# Altium schematic sheet XDS100V3_JTAG.SchDoc
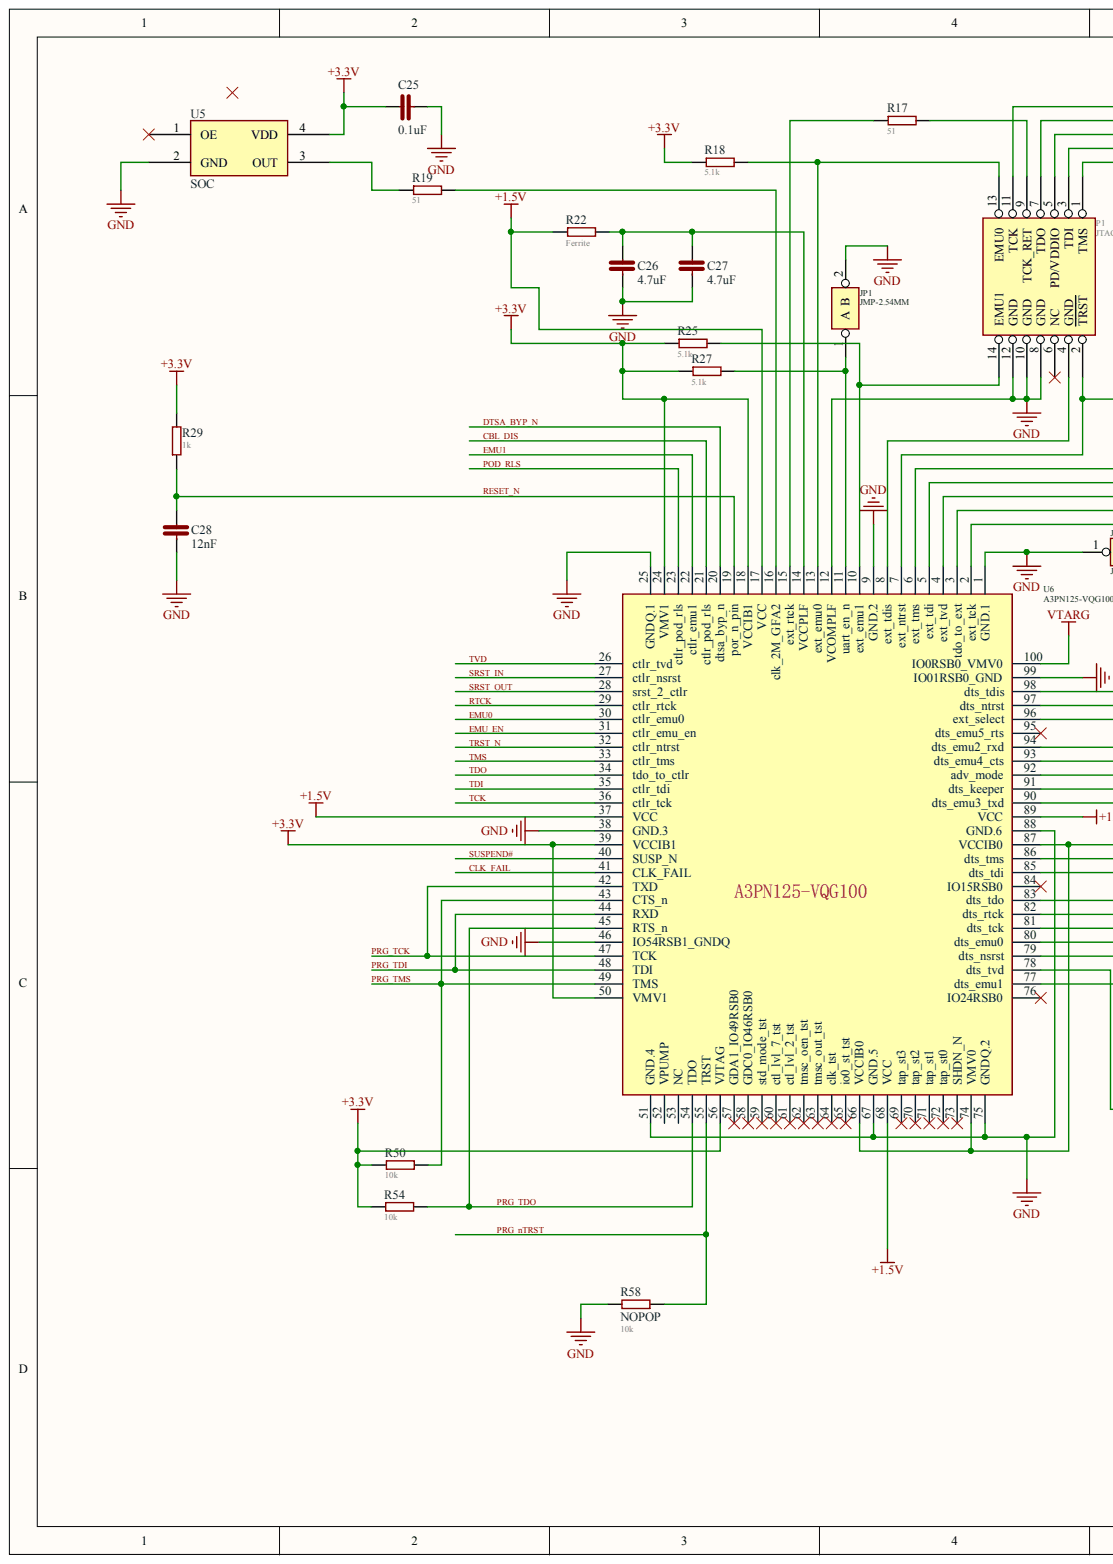
[diagram p0: XDS100V3_JTAG.SchDoc, left]
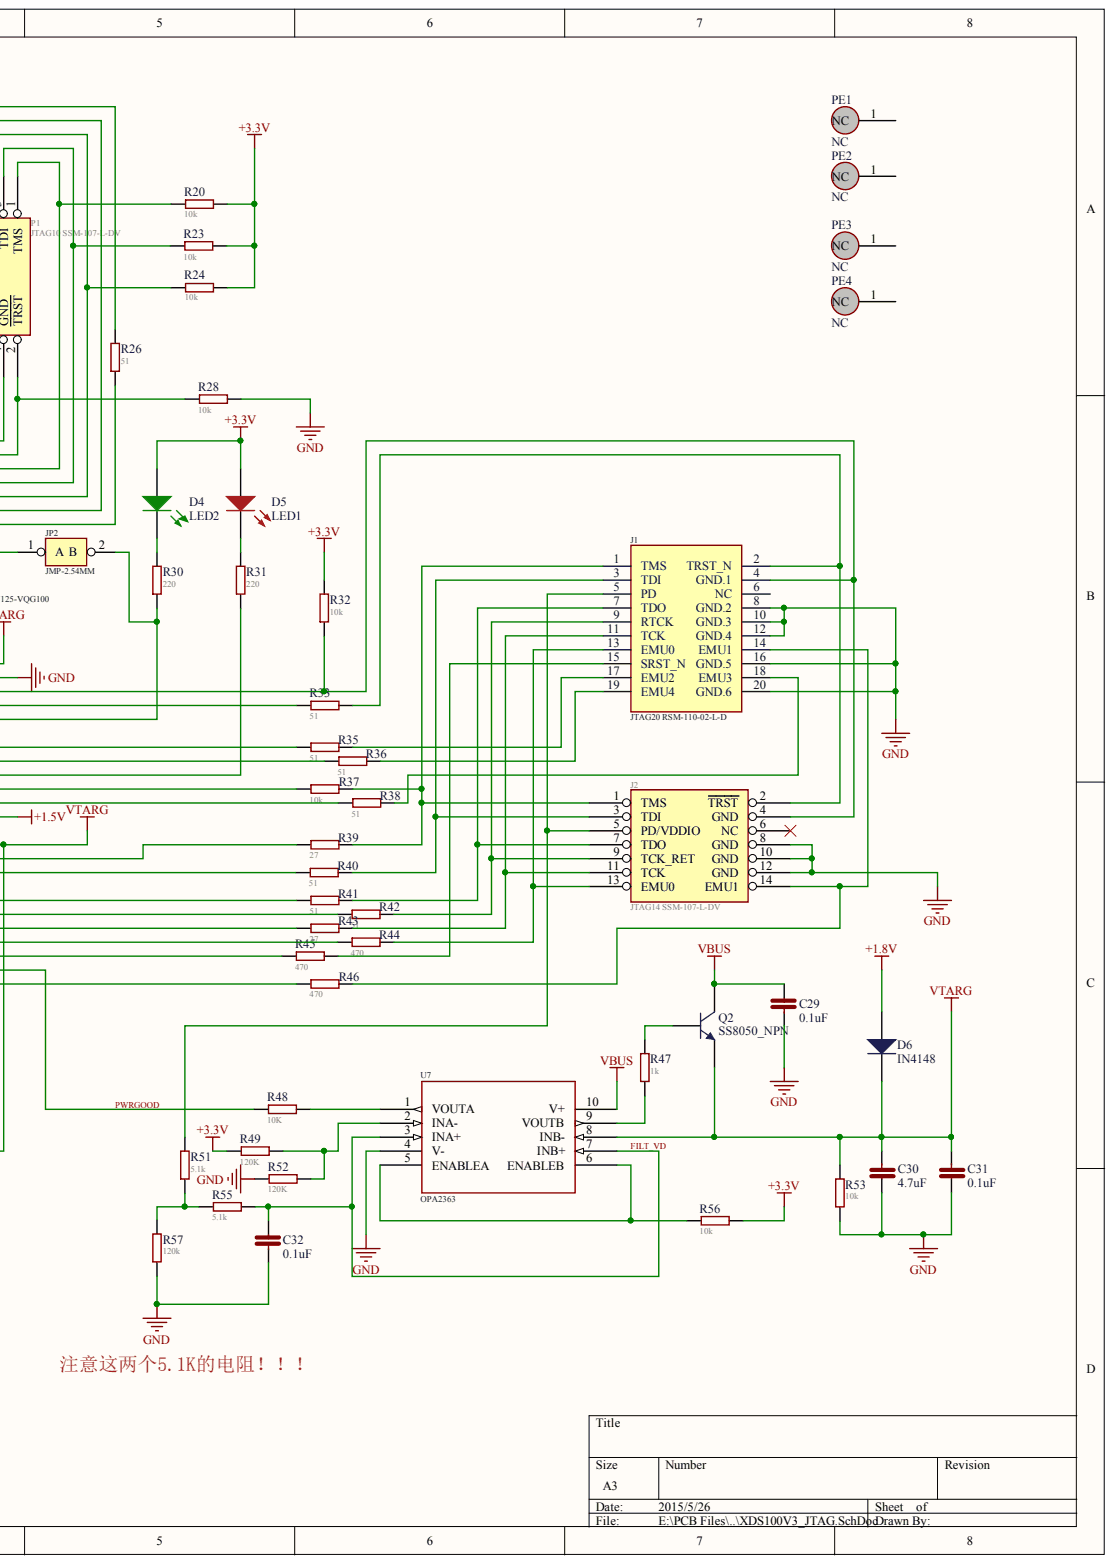
[diagram p1: XDS100V3_JTAG.SchDoc, right]

## Parts
C25  CAPACITOR NON-POL_1  [C-0805]  (value not drawn)
C26  CAPACITOR NON-POL_1  [C-0805]  (value not drawn)
C27  CAPACITOR NON-POL_1  [C-0805]  (value not drawn)
C28  CAPACITOR NON-POL_1  [C-0805]  (value not drawn)
C29  CAPACITOR NON-POL_1  [C-0805]  (value not drawn)
C30  CAPACITOR NON-POL_1  [C-0805]  (value not drawn)
C31  CAPACITOR NON-POL_1  [C-0805]  (value not drawn)
C32  CAPACITOR NON-POL_1  [C-0805]  (value not drawn)
D4  LED2  [LED-0805]
D5  LED1  [LED-0805]
D6  IN4148  [DO-41]
J1  JTAG20 RSM-110-02-L-D  [JTAG_10X2_2.54]
J2  JTAG14 SSM-107-L-DV  [JTAG_7X2_2.54]
JP1  JMP-2.54MM  [JUM1X2_2.54mm]
JP2  JMP-2.54MM  [JUM1X2_2.54mm]
P1  JTAG10 SSM-107-L-DV  [JTAG_7X2_2.54]
PE1  NC  [HOLE157-8STAR]
PE2  NC  [HOLE157-8STAR]
PE3  NC  [HOLE157-8STAR]
PE4  NC  [HOLE157-8STAR]
Q2  SS8050_NPN  [SOT-23-3L-SS8050]
R17  Res Semi  [R-0805_N]  (value not drawn)
R18  Res Semi  [R-0805_N]  (value not drawn)
R19  Res Semi  [R-0805_N]  (value not drawn)
R20  Res Semi  [R-0805_N]  (value not drawn)
R22  Res Semi  [R-0805_N]  (value not drawn)
R23  Res Semi  [R-0805_N]  (value not drawn)
R24  Res Semi  [R-0805_N]  (value not drawn)
R25  Res Semi  [R-0805_N]  (value not drawn)
R26  Res Semi  [R-0805_N]  (value not drawn)
R27  Res Semi  [R-0805_N]  (value not drawn)
R28  Res Semi  [R-0805_N]  (value not drawn)
R29  Res Semi  [R-0805_N]  (value not drawn)
R30  Res Semi  [R-0805_N]  (value not drawn)
R31  Res Semi  [R-0805_N]  (value not drawn)
R32  Res Semi  [R-0805_N]  (value not drawn)
R33  Res Semi  [R-0805_N]  (value not drawn)
R35  Res Semi  [R-0805_N]  (value not drawn)
R36  Res Semi  [R-0805_N]  (value not drawn)
R37  Res Semi  [R-0805_N]  (value not drawn)
R38  Res Semi  [R-0805_N]  (value not drawn)
R39  Res Semi  [R-0805_N]  (value not drawn)
R40  Res Semi  [R-0805_N]  (value not drawn)
R41  Res Semi  [R-0805_N]  (value not drawn)
R42  Res Semi  [R-0805_N]  (value not drawn)
R43  Res Semi  [R-0805_N]  (value not drawn)
R44  Res Semi  [R-0805_N]  (value not drawn)
R45  Res Semi  [R-0805_N]  (value not drawn)
R46  Res Semi  [R-0805_N]  (value not drawn)
R47  Res Semi  [R-0805_N]  (value not drawn)
R48  Res Semi  [R-0805_N]  (value not drawn)
R49  Res Semi  [R-0805_N]  (value not drawn)
R50  Res Semi  [R-0805_N]  (value not drawn)
R51  Res Semi  [R-0805_N]  (value not drawn)
R52  Res Semi  [R-0805_N]  (value not drawn)
R53  Res Semi  [R-0805_N]  (value not drawn)
R54  Res Semi  [R-0805_N]  (value not drawn)
R55  Res Semi  [R-0805_N]  (value not drawn)
R56  Res Semi  [R-0805_N]  (value not drawn)
R57  Res Semi  [R-0805_N]  (value not drawn)
R58  NOPOP  [R-0805_N]
U5  SOC  [SMD4_7X5]
U6  A3PN125-VQG100  [LQFP_100_PZ]
U7  OPA2363  [VSSOP_10]

## Nets
+1.5V: R22.1 U6.17(VCC) U6.37(VCC) U6.68(VCC) U6.89(VCC)
+1.8V: D6.1
+3.3V: C25.2 D4.1 D5.1 R18.1 R20.2 R23.2 R24.2 R25.1 R27.1 R29.2 R32.2 R49.1 R50.1 R54.1 R56.2 U5.4(VDD) U6.18(VCCIB1) U6.24(VMV1) U6.39(VCCIB1) U6.50(VMV1) U6.56(VJTAG)
GND: C25.1 C26.1 C27.1 C28.1 C29.1 C30.2 C31.2 C32.1 J1.8(GND.2) J1.10(GND.3) J1.12(GND.4) J1.16(GND.5) J1.20(GND.6) J2.8(GND) J2.10(GND) J2.12(GND) JP1.2 JP2.1 P1.8(GND) P1.10(GND) P1.12(GND) R28.2 R52.1 R53.1 R57.1 R58.2 U5.2(GND) U6.1(GND.1) U6.9(GND.2) U6.12(VCOMPLF) U6.25(GNDQ.1) U6.38(GND.3) U6.46(IO54RSB1_GNDQ) U6.51(GND.4) U6.67(GND.5) U6.75(GNDQ.2) U6.88(GND.6) U6.99(IO01RSB0_GND) U7.4(V-)
VBUS: C29.2 Q2.3 U7.10(V+)
VTARG: C30.1 C31.1 D6.2 Q2.2 R53.2 U6.66(VCCIB0) U6.74(VMV0) U6.87(VCCIB0) U6.100(IO0RSB0_VMV0) U7.8(INB-)
CBL_DIS: U6.21(ctlr_pod_rls)
CLK_FAIL: U6.41(CLK_FAIL)
DTSA_BYP_N: U6.20(dtsa_byp_n)
EMU0: U6.30(ctlr_emu0)
EMU1: U6.22(ctlr_emu1)
EMU_EN: U6.31(ctlr_emu_en)
FILT_VD: C32.2 R55.2 U7.3(INA+) U7.7(INB+)
POD_RLS: U6.23(ctlr_pod_rls)
PRG_NTRST: R58.1 U6.55(TRST)
PRG_TCK: U6.42(TXD) U6.47(TCK)
PRG_TDI: U6.44(RXD) U6.48(TDI)
PRG_TDO: R54.2 U6.45(RTS_n) U6.54(TDO)
PRG_TMS: R50.2 U6.43(CTS_n) U6.49(TMS)
PWRGOOD: R48.1 U6.78(dts_tvd)
RESET_N: C28.2 R29.1 U6.19(por_n_pin)
RTCK: U6.29(ctlr_rtck)
SRST_IN: U6.27(ctlr_nsrst)
SRST_OUT: U6.28(srst_2_ctlr)
SUSPEND#: U6.40(SUSP_N)
TCK: U6.36(ctlr_tck)
TDI: U6.35(ctlr_tdi)
TDO: U6.34(tdo_to_ctlr)
TMS: U6.33(ctlr_tms)
TRST_N: U6.32(ctlr_ntrst)
TVD: U6.26(ctlr_tvd)
NetC26_2: C26.2 C27.2 R22.2 U6.14(VCCPLF)
NetD4_2: D4.2 R30.2
NetD5_2: D5.2 R31.2
NetJ1_1: J1.1(TMS) J2.1(TMS) R37.2 R39.2
NetJ1_2: J1.2(TRST_N) J2.2(TRST) R33.2
NetJ1_3: J1.3(TDI) J2.3(TDI) R40.2
NetJ1_4: J1.4(GND.1) J2.4(GND) R32.1 U6.98(dts_tdis)
NetJ1_5: J1.5(PD) J2.5(PD/VDDIO) R51.2
NetJ1_7: J1.7(TDO) J2.7(TDO) R41.2
NetJ1_9: J1.9(RTCK) J2.9(TCK_RET) R42.2
NetJ1_11: J1.11(TCK) J2.11(TCK) R43.2
NetJ1_13: J1.13(EMU0) J2.13(EMU0) R44.2
NetJ1_14: J1.14(EMU1) J2.14(EMU1) R46.2
NetJ1_15: J1.15(SRST_N) R45.2
NetJ1_17: J1.17(EMU2) R35.2
NetJ1_18: J1.18(EMU3) R38.2
NetJ1_19: J1.19(EMU4) R36.2
NetJP1_1: JP1.1 R27.2 U6.11(uart_en_n)
NetJP2_2: JP2.2 R30.1 U6.96(ext_select)
NetP1_1: P1.1(TMS) R20.1 U6.6(ext_tms)
NetP1_2: P1.2(TRST) R28.1 U6.7(ext_ntrst)
NetP1_3: P1.3(TDI) R23.1 U6.5(ext_tdi)
NetP1_4: P1.4(GND) U6.8(ext_tdis)
NetP1_5: P1.5(PD/VDDIO) R24.1 U6.4(ext_tvd)
NetP1_7: P1.7(TDO) U6.3(tdo_to_ext)
NetP1_9: P1.9(TCK_RET) R17.2
NetP1_11: P1.11(TCK) R26.2
NetP1_13: P1.13(EMU0) R18.2 U6.13(ext_emu0)
NetP1_14: P1.14(EMU1) R25.2 U6.10(ext_emu1)
NetQ2_1: Q2.1 R47.2
NetR17_1: R17.1 U6.15(ext_rtck)
NetR19_1: R19.1 U5.3(OUT)
NetR19_2: R19.2 U6.16(clk_2M_GFA2)
NetR26_1: R26.1 U6.2(ext_tck)
NetR31_1: R31.1 U6.92(adv_mode)
NetR33_1: R33.1 U6.97(dts_ntrst)
NetR35_1: R35.1 U6.94(dts_emu2_rxd)
NetR36_1: R36.1 U6.93(dts_emu4_cts)
NetR37_1: R37.1 U6.91(dts_keeper)
NetR38_1: R38.1 U6.90(dts_emu3_txd)
NetR39_1: R39.1 U6.86(dts_tms)
NetR40_1: R40.1 U6.85(dts_tdi)
NetR41_1: R41.1 U6.83(dts_tdo)
NetR42_1: R42.1 U6.82(dts_rtck)
NetR43_1: R43.1 U6.81(dts_tck)
NetR44_1: R44.1 U6.80(dts_emu0)
NetR45_1: R45.1 U6.79(dts_nsrst)
NetR46_1: R46.1 U6.77(dts_emu1)
NetR47_1: R47.1 U7.9(VOUTB)
NetR48_2: R48.2 U7.1(VOUTA)
NetR49_2: R49.2 R52.2 U7.2(INA-)
NetR51_1: R51.1 R55.1 R57.2
NetR56_1: R56.1 U7.5(ENABLEA) U7.6(ENABLEB)

Not connected: J1 6(NC); J2 6(NC); P1 6(NC); PE1 1; PE2 1; PE3 1; PE4 1; U5 1(OE); U6 52(VPUMP) 53(NC) 57(GDA1_IO49RSB0) 58(GDC0_IO46RSB0) 59(std_mode_tst) 60(ctl_lvl_7_tst) 61(ctl_lvl_2_tst) 62(tmsc_oen_tst) 63(tmsc_out_tst) 64(clk_tst) 65(io0_st_tst) 69(tap_st3) 70(tap_st2) 71(tap_st1) 72(tap_st0) 73(SHDN_N) 76(IO24RSB0) 84(IO15RSB0) 95(dts_emu5_rts)

## Library data of the parts
C25-C32 = CAPACITOR NON-POL_1: Capacitor (Semiconductor SIM Model); Component Kind: Standard; Library Name: MyIntegrated_Library.IntLib
  C25 C29 C31 C32: : 0.1uF
  C26 C27 C30: : 4.7uF
  C28: : 12nF
D4 = LED2: Typical  GREEN GaAs LED; Component Kind: Standard; Library Name: MyIntegrated_Library.IntLib
D5 = LED1: Typical RED GaAs LED; Component Kind: Standard; Library Name: MyIntegrated_Library.IntLib
D6 = Silicon Low Leakage Diode: Component Kind: Standard; Description: Silicon Low Leakage Diode; Library Name: MyIntegrated_Library.IntLib
J2 P1 = TI_DSP_JTAG7X2: Component Kind: Standard; Library Name: MyIntegrated_Library.IntLib
JP1 JP2 = JUMPER 2A: Component Kind: Standard; Library Name: MyIntegrated_Library.IntLib
PE1-PE4 = 安装孔: Component Kind: Standard; Library Name: MyIntegrated_Library.IntLib
Q2 = SS8050_NPN: NPN Bipolar Transistor SS8050; Component Kind: Standard; Library Name: MyIntegrated_Library.IntLib
R17-R20 R22-R33 R35-R58 = Res Semi: Semiconductor Resistor; Component Kind: Standard; Library Name: MyIntegrated_Library.IntLib
  R17 R19 R26 R33 R35 R36 R38 R40-R42: : 51
  R18 R25 R27 R51 R55: : 5.1k
  R20 R23 R24 R28 R32 R37 R50 R53 R54 R56 R58: : 10k
  R22: : Ferrite
  R29 R47: : 1k
  R30 R31: : 220
  R39 R43: : 27
  R44-R46: : 470
  R48: : 10K
  R49 R52: : 120K
  R57: : 120k
J1 = JTAG20 RSM-110-02-L-D: Component Kind: Standard; Description: JTAG20 RSM-110-02-L-D; Library Name: MyIntegrated_Library.IntLib
U5 = SOC: Component Kind: Standard; Library Name: MyIntegrated_Library.IntLib
U6 = A3PN125-VQG100: Component Kind: Standard; Description: A3PN125-VQG100; Library Name: MyIntegrated_Library.IntLib
U7 = OPA2363: Component Kind: Standard; Description: OPA2363; Library Name: MyIntegrated_Library.IntLib
